# Altium schematic sheet 01.Main.SchDoc
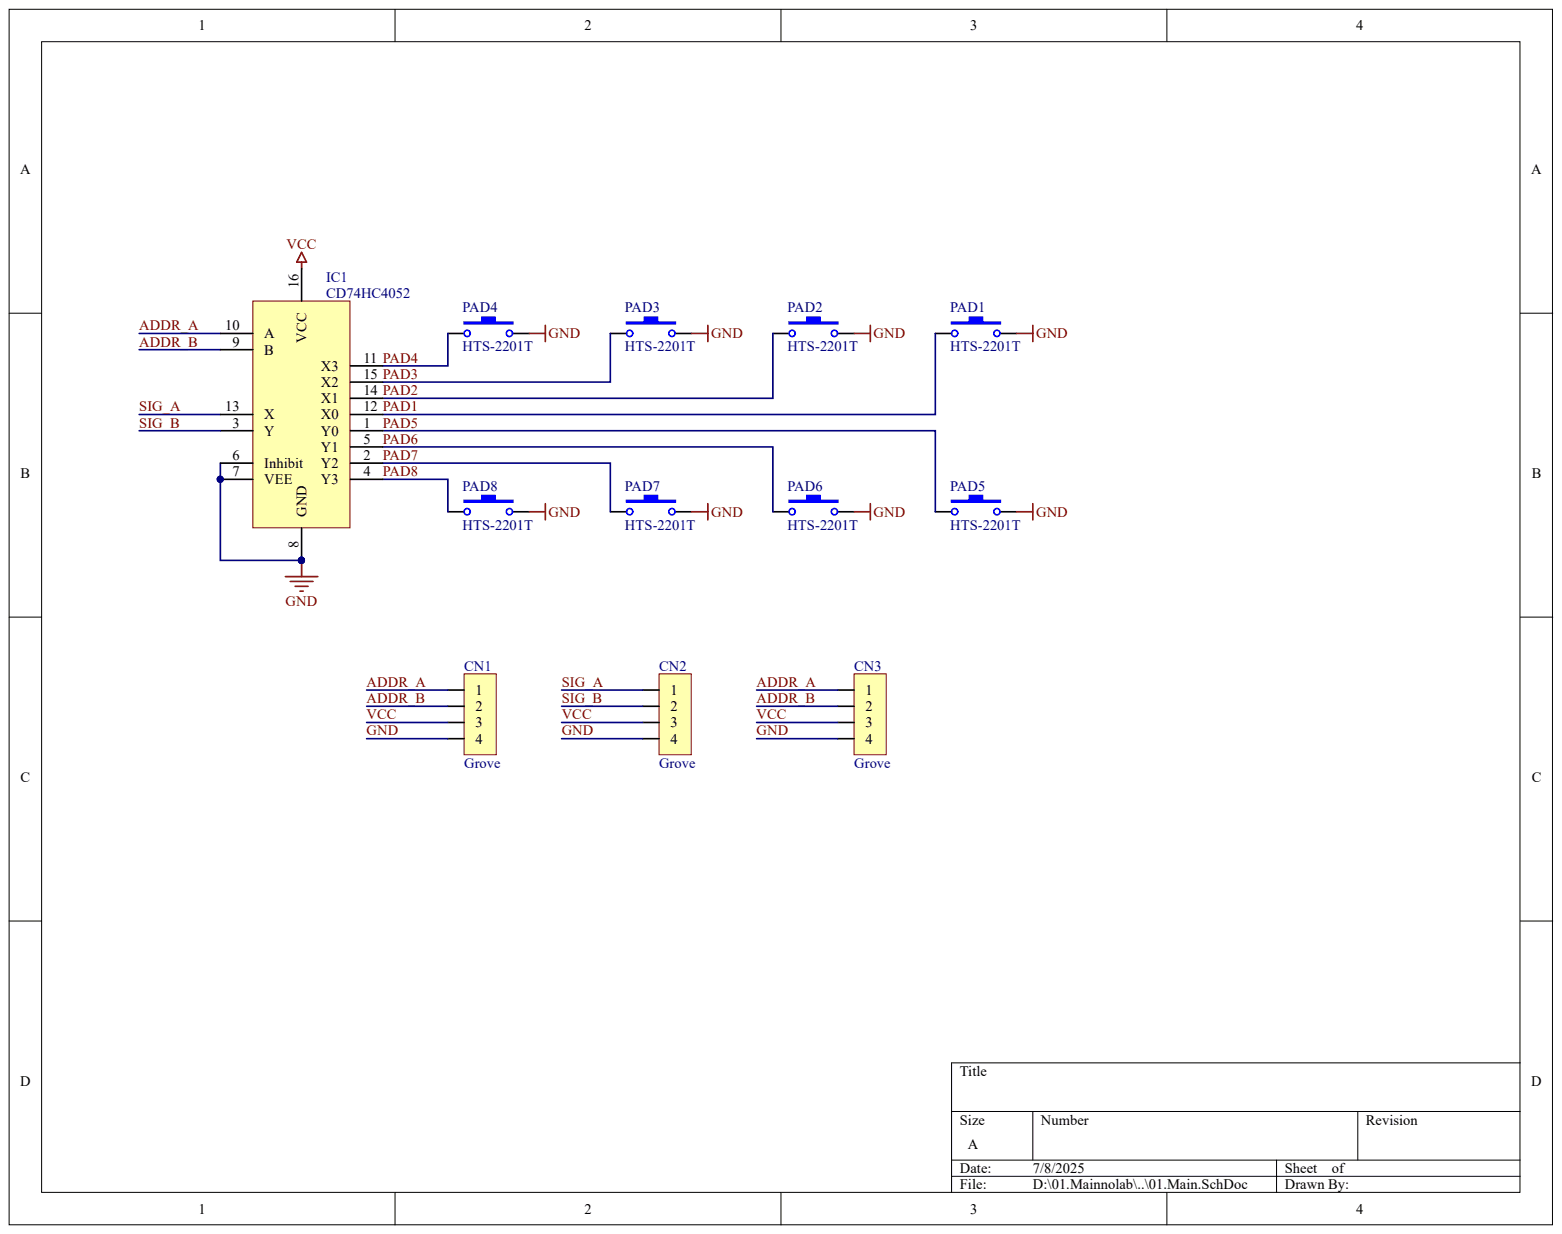
[diagram p0: 01.Main.SchDoc]

## Parts
CN1  Grove
CN2  Grove
CN3  Grove
IC1  CD74HC4052
PAD1  HTS-2201T
PAD2  HTS-2201T
PAD3  HTS-2201T
PAD4  HTS-2201T
PAD5  HTS-2201T
PAD6  HTS-2201T
PAD7  HTS-2201T
PAD8  HTS-2201T

## Nets
ADDR_A: CN1.1 CN3.1 IC1.10(A)
ADDR_B: CN1.2 CN3.2 IC1.9(B)
GND: CN1.4 CN2.4 CN3.4 IC1.6(Inhibit) IC1.7(VEE) IC1.8(GND) PAD1.1 PAD2.1 PAD3.1 PAD4.1 PAD5.1 PAD6.1 PAD7.1 PAD8.1
PAD1: IC1.12(X0) PAD1.2
PAD2: IC1.14(X1) PAD2.2
PAD3: IC1.15(X2) PAD3.2
PAD4: IC1.11(X3) PAD4.2
PAD5: IC1.1(Y0) PAD5.2
PAD6: IC1.5(Y1) PAD6.2
PAD7: IC1.2(Y2) PAD7.2
PAD8: IC1.4(Y3) PAD8.2
SIG_A: CN2.1 IC1.13(X)
SIG_B: CN2.2 IC1.3(Y)
VCC: CN1.3 CN2.3 CN3.3 IC1.16(VCC)
